# Altium schematic sheet POWER.SchDoc
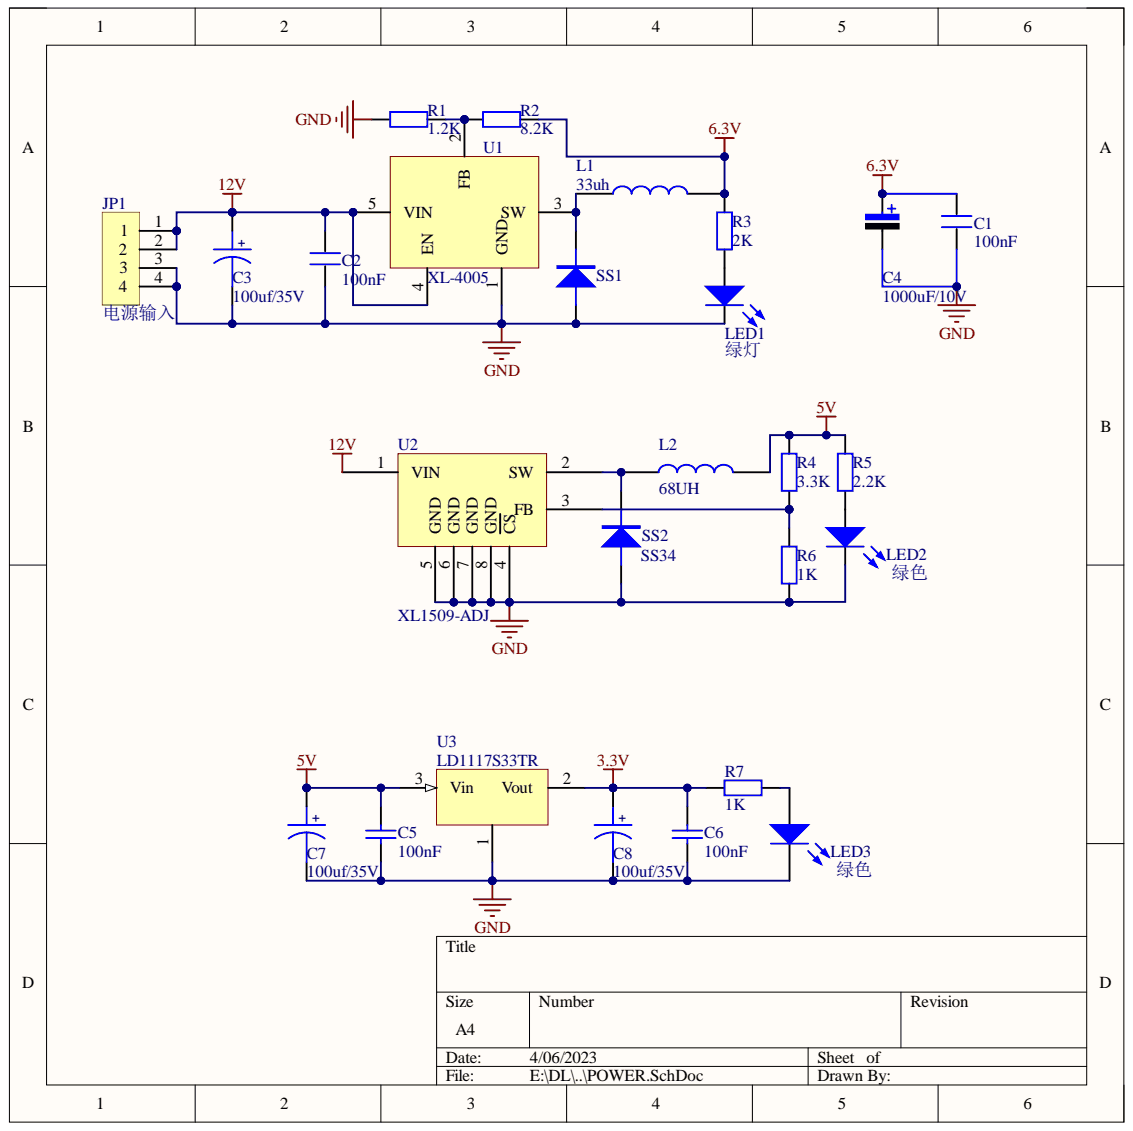
[diagram p0: POWER.SchDoc]

## Parts
C1  100nF  [0603_C]
C2  100nF  [0603_C]
C3  100uf/35V  [CM C(5*5.4)]
C4  1000uF/10V  [C-SMT-D8]
C5  100nF  [0402_C]
C6  100nF  [0402_C]
C7  100uf/35V  [CM C(5*5.4)]
C8  100uf/35V  [CM C(5*5.4)]
JP1  电源输入  [卧贴式XH2.54-4P]
L1  33uh  [L-SMD-12.5]
L2  68UH  [L-SMD-7]
LED1  绿灯  [LED 0603G]
LED2  绿色  [LED 0603G]
LED3  绿色  [LED 0603G]
R1  1.2K  [0402_R]
R2  8.2K  [0402_R]
R3  2K  [0402_R]
R4  3.3K  [0402_R]
R5  2.2K  [0402_R]
R6  1K  [0402_R]
R7  1K  [0402_R]
SS1  (no value)  [Diode-SMB]
SS2  SS34  [Diode-SMB]
U1  XL-4005  [TO-263-5]
U2  XL1509-ADJ  [SOP8_M]
U3  LD1117S33TR  [LM1117M3-3.3-SOP223]

## Nets
3.3V: C6.1 C8.1 R7.1 U3.2
5V: C5.1 C7.1 L2.2 R4.2 R5.2 U3.3
6.3V: C1.2 C4.1 L1.2 R2.1 R3.1
12V: C2.2 C3.1 JP1.1 JP1.2 U1.4(EN) U1.5(VIN) U2.1(VIN)
GND: C1.1 C2.1 C3.2 C4.2 C5.2 C6.2 C7.2 C8.2 JP1.3 JP1.4 LED1.2 LED2.2 LED3.2 R1.2 R6.1 SS1.A SS2.A U1.1(GND) U2.4(CS) U2.5(GND) U2.6(GND) U2.7(GND) U2.8(GND) U3.1
NetL1_1: L1.1 SS1.K U1.3(SW)
NetL2_1: L2.1 SS2.K U2.2(SW)
NetLED1_1: LED1.1 R3.2
NetLED2_1: LED2.1 R5.1
NetLED3_1: LED3.1 R7.2
NetR1_1: R1.1 R2.2 U1.2(FB)
NetR4_1: R4.1 R6.2 U2.3(FB)

## Library data of the parts
C1 C2 = C: Component Kind: Standard; Library Name: 103驱动2.SCHLIB
C3 C7 C8 = CAP-2: Component Kind: Standard; Library Name: ZBC124Schlib1.SchLib
C4 = Cap Pol: 极性电解电容; Value: 100uF; Vol: 16V; Component Kind: Standard; LatestRevisionDate: 17-Jul-2002; LatestRevisionNote: Re-released for DXP Platform.; PackageReference: RB7.6-15; Published: 8-Jun-2000; Library Name: 103驱动2.SCHLIB; Publisher: Altium Limited
C5 C6 = Cap: 无极性电容; Component Kind: Standard; LatestRevisionDate: 17-Jul-2002; LatestRevisionNote: Re-released for DXP Platform.; PackageReference: RAD-0.3; Published: 8-Jun-2000; Value: 100nF; Library Name: Fszhang.IntLib; Publisher: Altium Limited
JP1 = S-4: Component Kind: Standard; Library Name: ZBC123Schlib1.SchLib
L1 L2 = Inductance: Component Kind: Standard; Library Name: 103驱动2.SCHLIB
LED1 LED2 = LED: Component Kind: Standard; Library Name: 103驱动2.SCHLIB
LED3 = LED0: 发光二极管; Component Kind: Standard; LatestRevisionDate: 08-Jul-2005; LatestRevisionNote: Mech Layer 1 removed.; PackageReference: LED-0; Published: 8-Jun-2000; Library Name: Fszhang.IntLib; Publisher: Altium Limited
R1-R3 = Res: Component Kind: Standard; Library Name: 103驱动2.SCHLIB
R4 R5 = Res1: 贴片电阻; Value: 1K; Component Kind: Standard; LatestRevisionDate: 17-Jul-2002; LatestRevisionNote: Re-released for DXP Platform.; PackageReference: AXIAL-0.4; Published: 8-Jun-2000; Library Name: Fszhang.IntLib; Publisher: Altium Limited
R6 R7 = Res1: 贴片电阻; Component Kind: Standard; LatestRevisionDate: 17-Jul-2002; LatestRevisionNote: Re-released for DXP Platform.; PackageReference: AXIAL-0.4; Published: 8-Jun-2000; Value: 1K; Library Name: Fszhang.IntLib; Publisher: Altium Limited
SS1 SS2 = M7: Component Kind: Standard; Library Name: 103驱动2.SCHLIB
U1 = LM2576SX-5.0_[C34465]: DC-DC芯片; Component Kind: Standard; ComponentLink1Description: 供应商链接; ComponentLink1URL: http://www.szlcsc.com/product/details_35434.html; Library Name: JLC.IntLib; Package: TO-263-5; Supplier: LC; SuppliersPartNumber: C34465
U3 = LD1117: Component Kind: Standard
U2 = XL1509-ADJ: Component Kind: Standard; Library Name: 103驱动2.SCHLIB
